# Altium schematic sheet aux_power_low_vol.SchDoc(aux_power_low_vol)
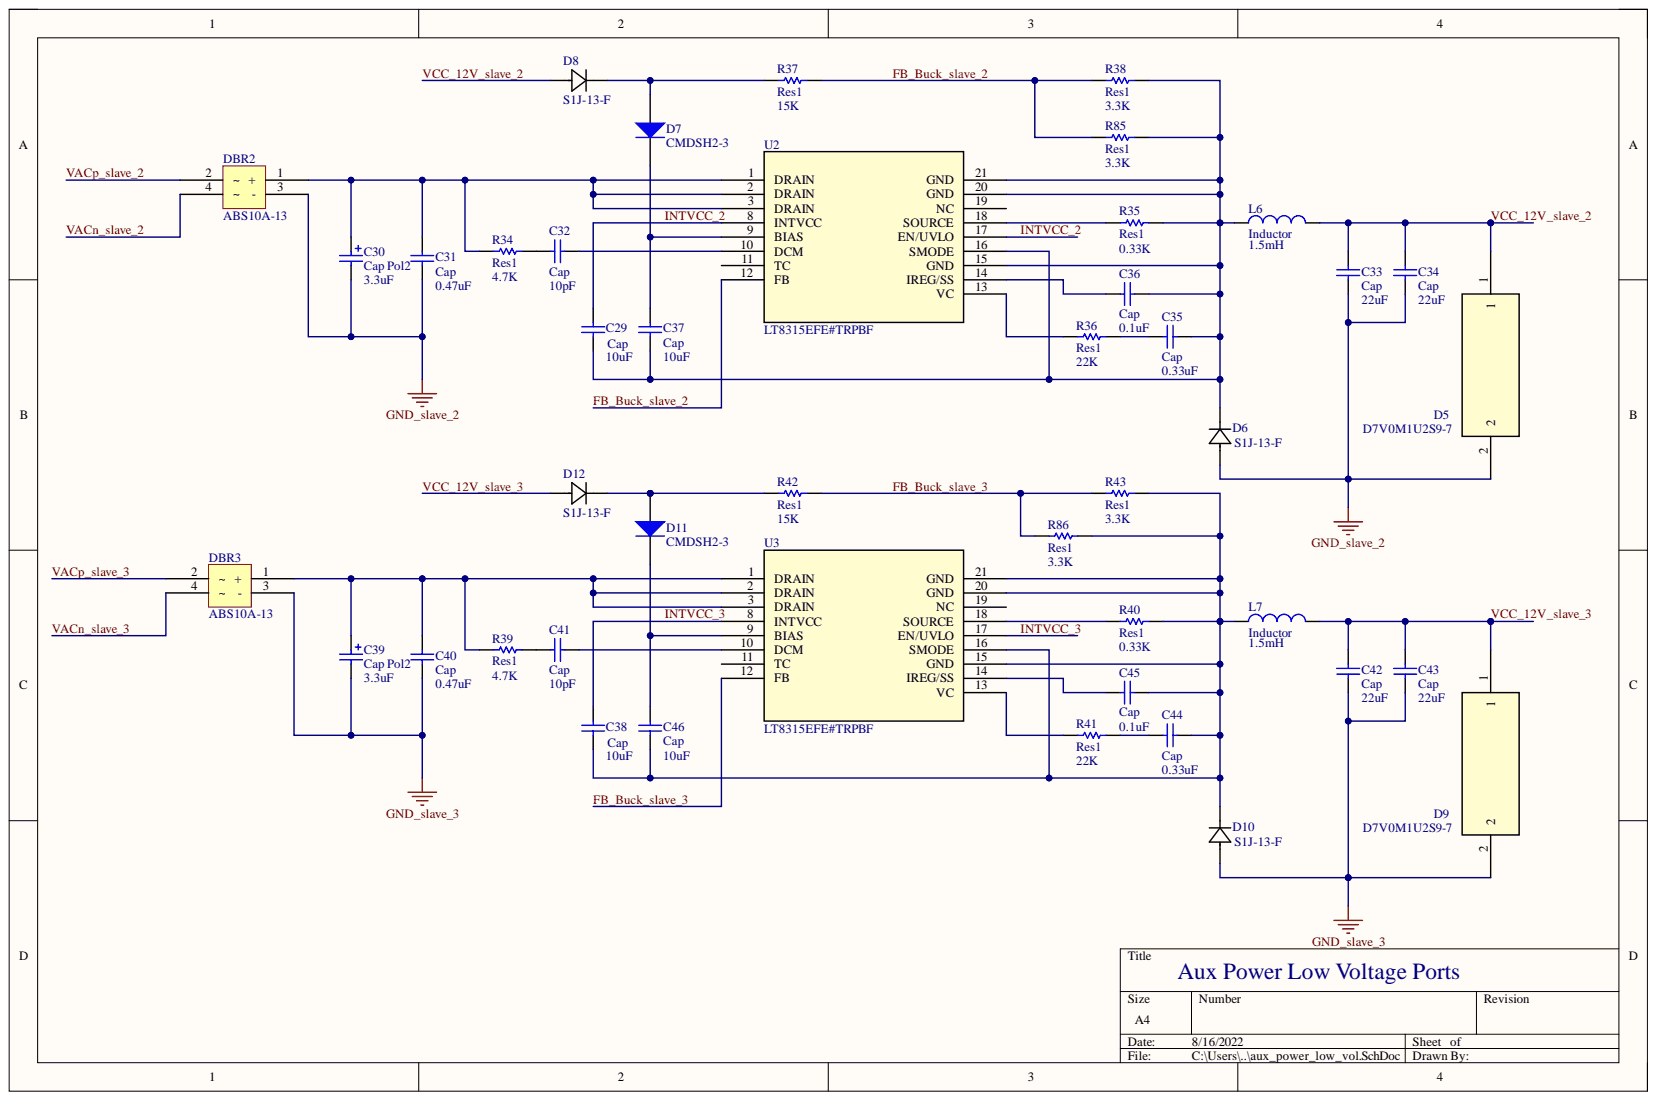
[diagram p0: aux_power_low_vol.SchDoc]

## Parts
C29  Cap  value 10uF  [C0805]
C30  Cap Pol2  value 3.3uF  [Capacitor_P_3.3uF]
C31  Cap  value 0.47uF  [C0805]
C32  Cap  value 10pF  [C0805]
C33  Cap  value 22uF  [C0805]
C34  Cap  value 22uF  [C0805]
C35  Cap  value 0.33uF  [C0805]
C36  Cap  value 0.1uF  [C0805]
C37  Cap  value 10uF  [C0805]
C38  Cap  value 10uF  [C0805]
C39  Cap Pol2  value 3.3uF  [Capacitor_P_3.3uF]
C40  Cap  value 0.47uF  [C0805]
C41  Cap  value 10pF  [C0805]
C42  Cap  value 22uF  [C0805]
C43  Cap  value 22uF  [C0805]
C44  Cap  value 0.33uF  [C0805]
C45  Cap  value 0.1uF  [C0805]
C46  Cap  value 10uF  [C0805]
D5  D7V0M1U2S9-7  [D7V0M1U2S9-7_DIO]
D6  S1J-13-F  [SMA_DIO]
D7  CMDSH2-3  [SOD-323]
D8  S1J-13-F  [SMA_DIO]
D9  D7V0M1U2S9-7  [D7V0M1U2S9-7_DIO]
D10  S1J-13-F  [SMA_DIO]
D11  CMDSH2-3  [SOD-323]
D12  S1J-13-F  [SMA_DIO]
DBR2  ABS10A-13  [ABS10A-13]
DBR3  ABS10A-13  [ABS10A-13]
L6  Inductor  value 1.5mH  [Inductor_1.5mH]
L7  Inductor  value 1.5mH  [Inductor_1.5mH]
R34  Res1  value 4.7K  [RES0805W]
R35  Res1  value 0.33K  [RES0805W]
R36  Res1  value 22K  [RES0805W]
R37  Res1  value 15K  [RES0805W]
R38  Res1  value 3.3K  [RES0805W]
R39  Res1  value 4.7K  [RES0805W]
R40  Res1  value 0.33K  [RES0805W]
R41  Res1  value 22K  [RES0805W]
R42  Res1  value 15K  [RES0805W]
R43  Res1  value 3.3K  [RES0805W]
R85  Res1  value 3.3K  [RES0805W]
R86  Res1  value 3.3K  [RES0805W]
U2  LT8315EFE#TRPBF  [FE_20BB_ADI]
U3  LT8315EFE#TRPBF  [FE_20BB_ADI]

## Nets
GND_slave_2: C30.2 C31.1 C33.1 C34.1 D5.2 D6.2 DBR2.3(-)
GND_slave_3: C39.2 C40.1 C42.1 C43.1 D9.2 D10.2 DBR3.3(-)
FB_Buck_slave_2: R37.2 R38.1 R85.1 U2.12(FB)
FB_Buck_slave_3: R42.2 R43.1 R86.1 U3.12(FB)
INTVCC_2: C29.2 U2.8(INTVCC) U2.17(EN/UVLO)
INTVCC_3: C38.2 U3.8(INTVCC) U3.17(EN/UVLO)
VACn_slave_2: DBR2.4(~)
VACn_slave_3: DBR3.4(~)
VACp_slave_2: DBR2.2(~)
VACp_slave_3: DBR3.2(~)
VCC_12V_slave_2: C33.2 C34.2 D5.1 D8.2 L6.2
VCC_12V_slave_3: C42.2 C43.2 D9.1 D12.2 L7.2
NetC29_1: C29.1 C35.1 C36.1 C37.1 D6.1 L6.1 R35.2 R38.2 R85.2 U2.15(GND) U2.16(SMODE) U2.20(GND) U2.21(GND)
NetC30_1: C30.1 C31.2 DBR2.1(+) R34.1 U2.1(DRAIN) U2.2(DRAIN) U2.3(DRAIN)
NetC32_1: C32.1 U2.10(DCM)
NetC32_2: C32.2 R34.2
NetC35_2: C35.2 R36.2
NetC36_2: C36.2 U2.14(IREG/SS)
NetC37_2: C37.2 D7.2 U2.9(BIAS)
NetC38_1: C38.1 C44.1 C45.1 C46.1 D10.1 L7.1 R40.2 R43.2 R86.2 U3.15(GND) U3.16(SMODE) U3.20(GND) U3.21(GND)
NetC39_1: C39.1 C40.2 DBR3.1(+) R39.1 U3.1(DRAIN) U3.2(DRAIN) U3.3(DRAIN)
NetC41_1: C41.1 U3.10(DCM)
NetC41_2: C41.2 R39.2
NetC44_2: C44.2 R41.2
NetC45_2: C45.2 U3.14(IREG/SS)
NetC46_2: C46.2 D11.2 U3.9(BIAS)
NetD7_1: D7.1 D8.1 R37.1
NetD11_1: D11.1 D12.1 R42.1
NetR35_1: R35.1 U2.18(SOURCE)
NetR36_1: R36.1 U2.13(VC)
NetR40_1: R40.1 U3.18(SOURCE)
NetR41_1: R41.1 U3.13(VC)

Not connected: U2 11(TC) 19(NC); U3 11(TC) 19(NC)

## Library data of the parts
C29 C31-C35 C37 C38 C40-C44 C46 = Cap: Capacitor; Component Kind: Standard; LatestRevisionDate: 17-Jul-2002; LatestRevisionNote: Re-released for DXP Platform.; Library Name: Miscellaneous Devices.IntLib; PackageDescription: Radial Cap, Thru-Hole; 2 Leads; 0.3 in Pin Spacing; PackageReference: RAD-0.3; Published: 8-Jun-2000; Signal Integrity: Cap; Simulation: CAP; Publisher: Altium Limited
C30 C39 = Cap Pol2: Polarized Capacitor (Axial); Component Kind: Standard; LatestRevisionDate: 17-Jul-2002; LatestRevisionNote: Re-released for DXP Platform.; Library Name: Miscellaneous Devices.IntLib; PackageDescription: Polarized Capacitor; 2 Leads; PackageReference: POLAR0.8; Published: 8-Jun-2000; Signal Integrity: Cap Pol; Simulation: CAP; Publisher: Altium Limited
C36 C45 = Cap: Capacitor; Value: 0.1uF; Component Kind: Standard; LatestRevisionDate: 17-Jul-2002; LatestRevisionNote: Re-released for DXP Platform.; Library Name: Miscellaneous Devices.IntLib; PackageDescription: Radial Cap, Thru-Hole; 2 Leads; 0.3 in Pin Spacing; PackageReference: RAD-0.3; Published: 8-Jun-2000; Signal Integrity: Cap; Simulation: CAP; Publisher: Altium Limited
D5 D9 = D7V0M1U2S9-7: 13V; Mfr_Name: Diodes Inc; Type: DEV; Component Kind: Standard; Digi-Key_Part_Number_1: 31-D7V0M1U2S9-7TR-ND; Digi-Key_Part_Number_2: 31-D7V0M1U2S9-7CT-ND; Digi-Key_Part_Number_3: 31-D7V0M1U2S9-7DKR-ND; Library Name: D7V0M1U2S9-7.SchLib; Manufacturer_Part_Number: D7V0M1U2S9-7; RefDes: RefDes; Copyright: Copyright (C) 2022 Ultra Librarian. All rights reserved.
D6 D8 D10 D12 = S1J-13-F: No Description Available; Mfr_Name: Diodes Inc; Type: DEV; Component Kind: Standard; Digi-Key_Part_Number_1: S1J-FDICT-ND; Digi-Key_Part_Number_2: S1J-FDIDKR-ND; Digi-Key_Part_Number_3: S1J-FDITR-ND; Library Name: S1J-13-F.SchLib; Manufacturer_Part_Number: S1J-13-F; RefDes: RefDes; Copyright: Copyright (C) 2022 Ultra Librarian. All rights reserved.
D7 D11 = Diode: Default Diode; Code_JEDEC: DO-214-AB; Component Kind: Standard; LatestRevisionDate: 17-Jul-2002; LatestRevisionNote: Re-released for DXP Platform.; Library Name: Miscellaneous Devices.IntLib; PackageDescription: DO-214-AB/SMC; 2 C-Bend Leads; Body 7.9 x 5.9 mm, inc. leads (LxW); PackageReference: SMC; PackageVersion: Sep-1996; Published: 8-Jun-2000; Signal Integrity: Diode; Simulation: DIODE; Publisher: Altium Limited
L6 L7 = Inductor: Code_IEC: 1005; Code_JEITA: 0402; Component Kind: Standard; Description: Inductor; LatestRevisionDate: 29-May-2009; LatestRevisionNote: IPC-7351 Footprint Added.; Library Name: Miscellaneous Devices.IntLib; PackageDescription: Chip Inductor; PackageReference: 0402-A; Published: 8-Jun-2000; Signal Integrity: Inductor; Simulation: INDUCTOR; Publisher: Altium Limited
R34 R36 R37 R39 R41-R43 R85 R86 = Res1: Resistor; Component Kind: Standard; LatestRevisionDate: 17-Jul-2002; LatestRevisionNote: Re-released for DXP Platform.; Library Name: Miscellaneous Devices.IntLib; PackageDescription: Axial Device, Thru-Hole; 2 Leads; 0.3 in Pin Spacing; PackageReference: AXIAL-0.3; Published: 8-Jun-2000; Signal Integrity: Res; Simulation: RESISTOR; Publisher: Altium Limited
R35 R40 = Res1: Resistor; Value: 0.33K; Component Kind: Standard; LatestRevisionDate: 17-Jul-2002; LatestRevisionNote: Re-released for DXP Platform.; Library Name: Miscellaneous Devices.IntLib; PackageDescription: Axial Device, Thru-Hole; 2 Leads; 0.3 in Pin Spacing; PackageReference: AXIAL-0.3; Published: 8-Jun-2000; Signal Integrity: Res; Simulation: RESISTOR; Publisher: Altium Limited
R38 = Res1: Resistor; Value: 3.3K; Component Kind: Standard; LatestRevisionDate: 17-Jul-2002; LatestRevisionNote: Re-released for DXP Platform.; Library Name: Miscellaneous Devices.IntLib; PackageDescription: Axial Device, Thru-Hole; 2 Leads; 0.3 in Pin Spacing; PackageReference: AXIAL-0.3; Published: 8-Jun-2000; Signal Integrity: Res; Simulation: RESISTOR; Publisher: Altium Limited
U2 U3 = LT8315EFE#TRPBF: No Description Available; Mfr_Name: Analog Devices Inc; Component Kind: Standard; Digi-Key_Part_Number_1: LT8315EFE#TRPBF-ND; Manufacturer_Part_Number: LT8315EFE#TRPBF; RefDes: RefDes; Type: DEV; Copyright: Copyright (C) 2022 Ultra Librarian. All rights reserved.; Library Name: LT8315EFE&hash_TRPBF.SchLib
DBR2 DBR3 = ABS10A-13: Component Kind: Standard; Library Name: ABS10A-13.SchLib
